# Altium schematic sheet Schematic.SchDoc
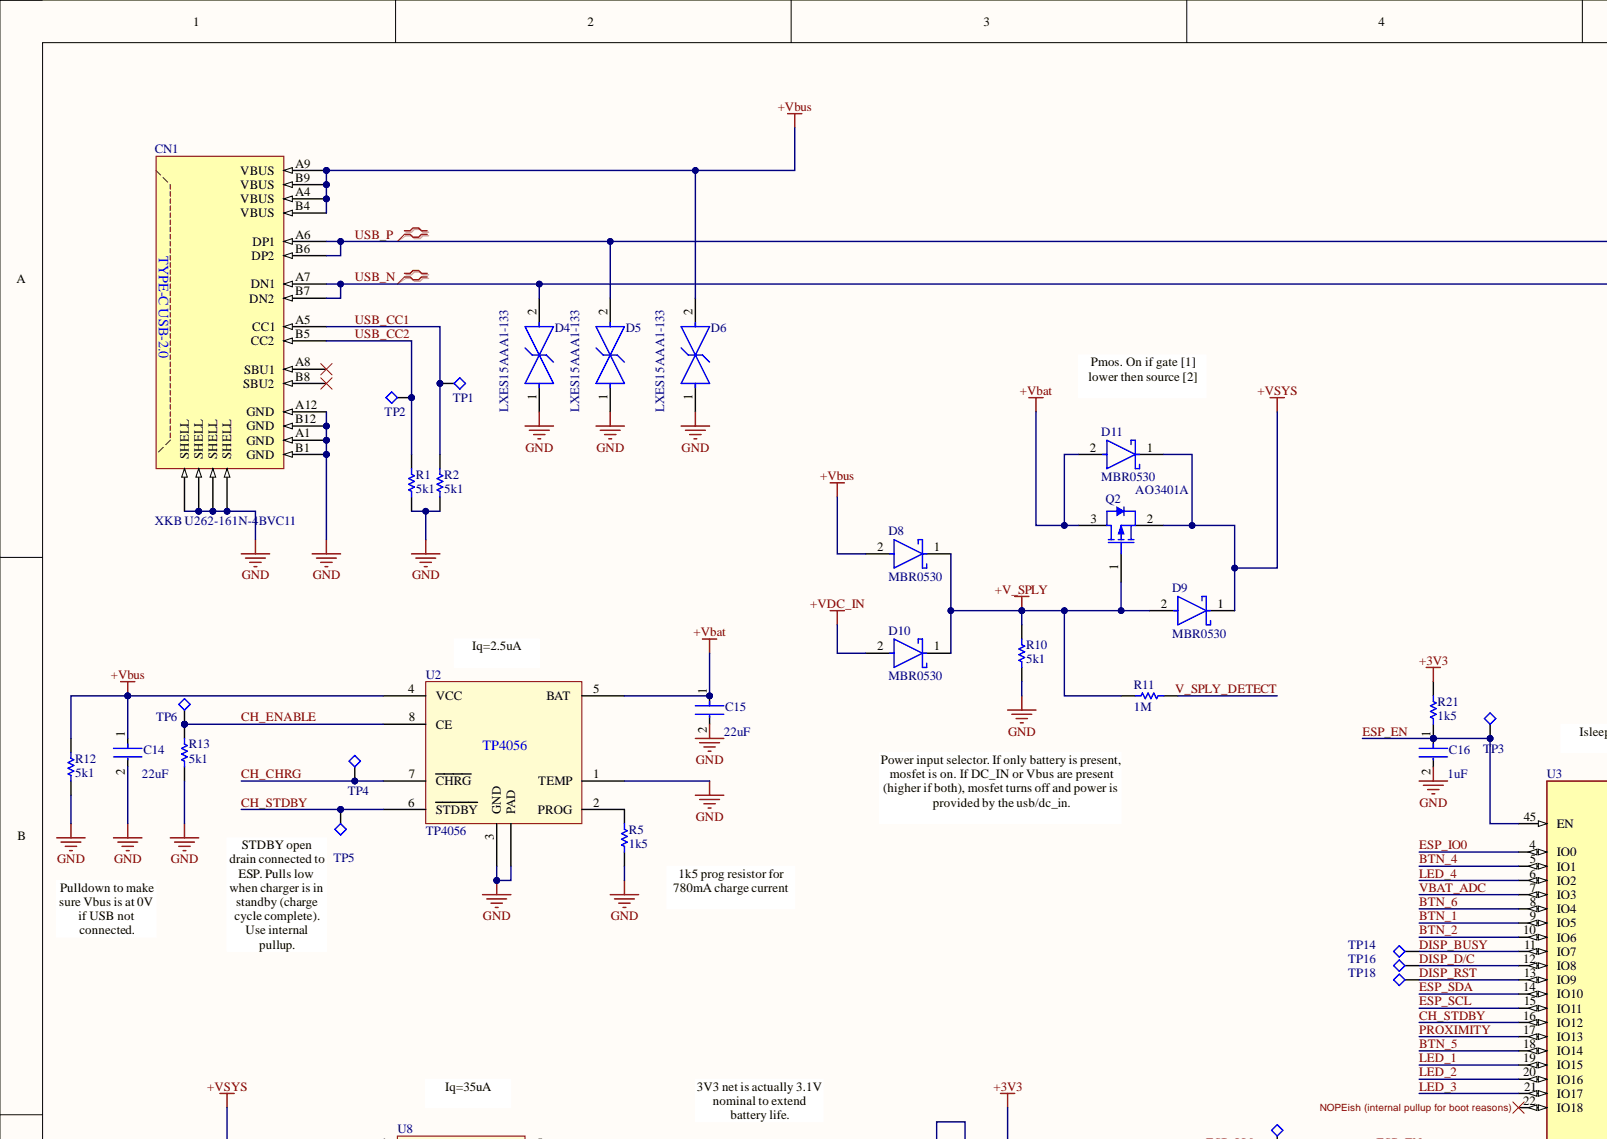
[diagram p0: Schematic.SchDoc, top left]
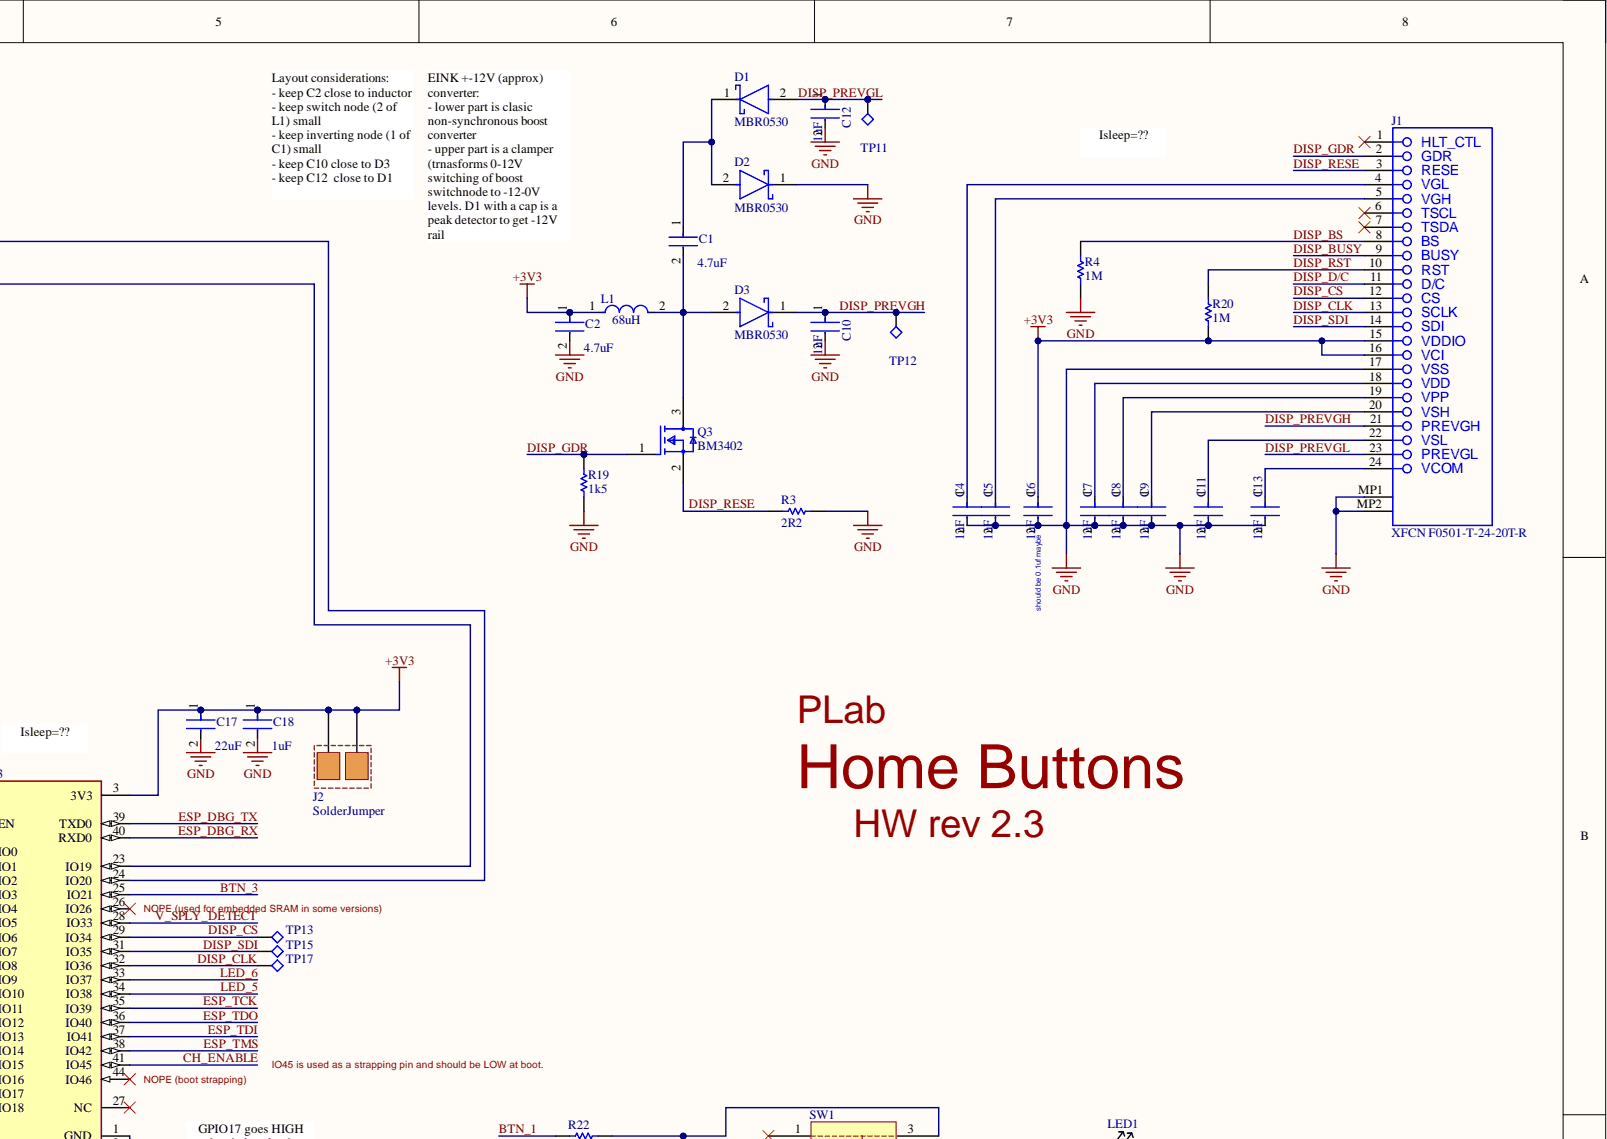
[diagram p1: Schematic.SchDoc, top right]
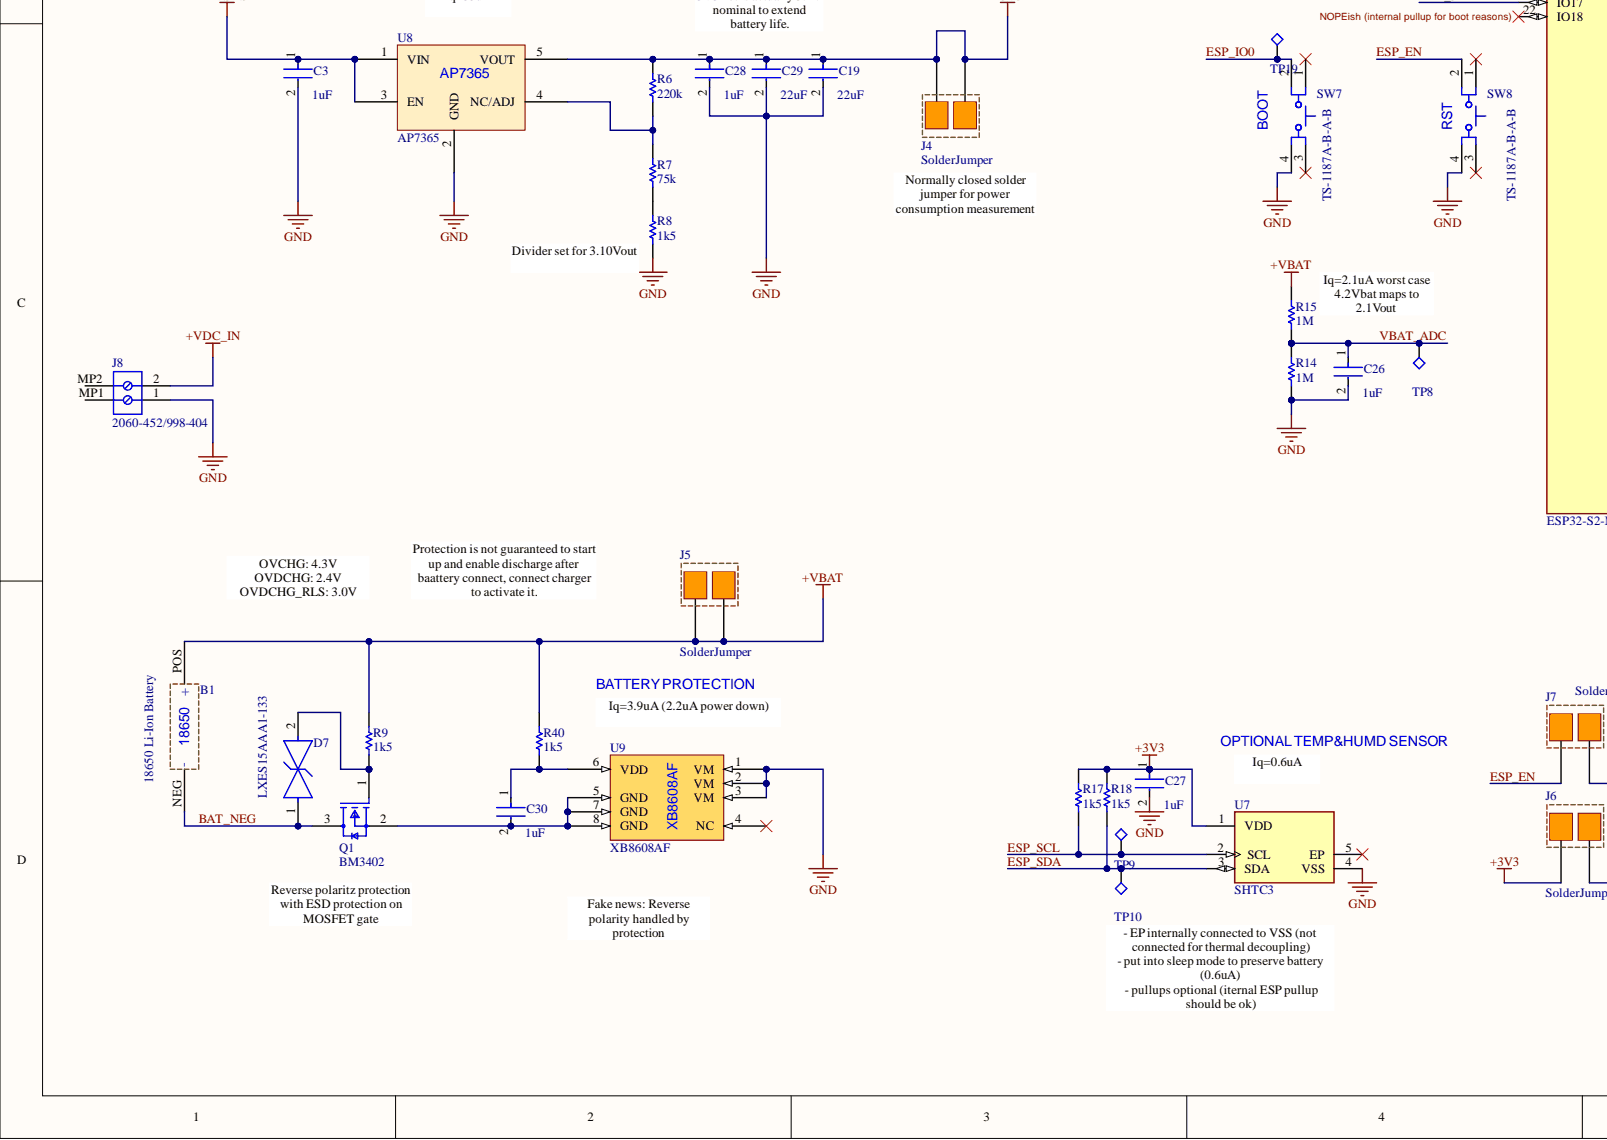
[diagram p2: Schematic.SchDoc, bottom left]
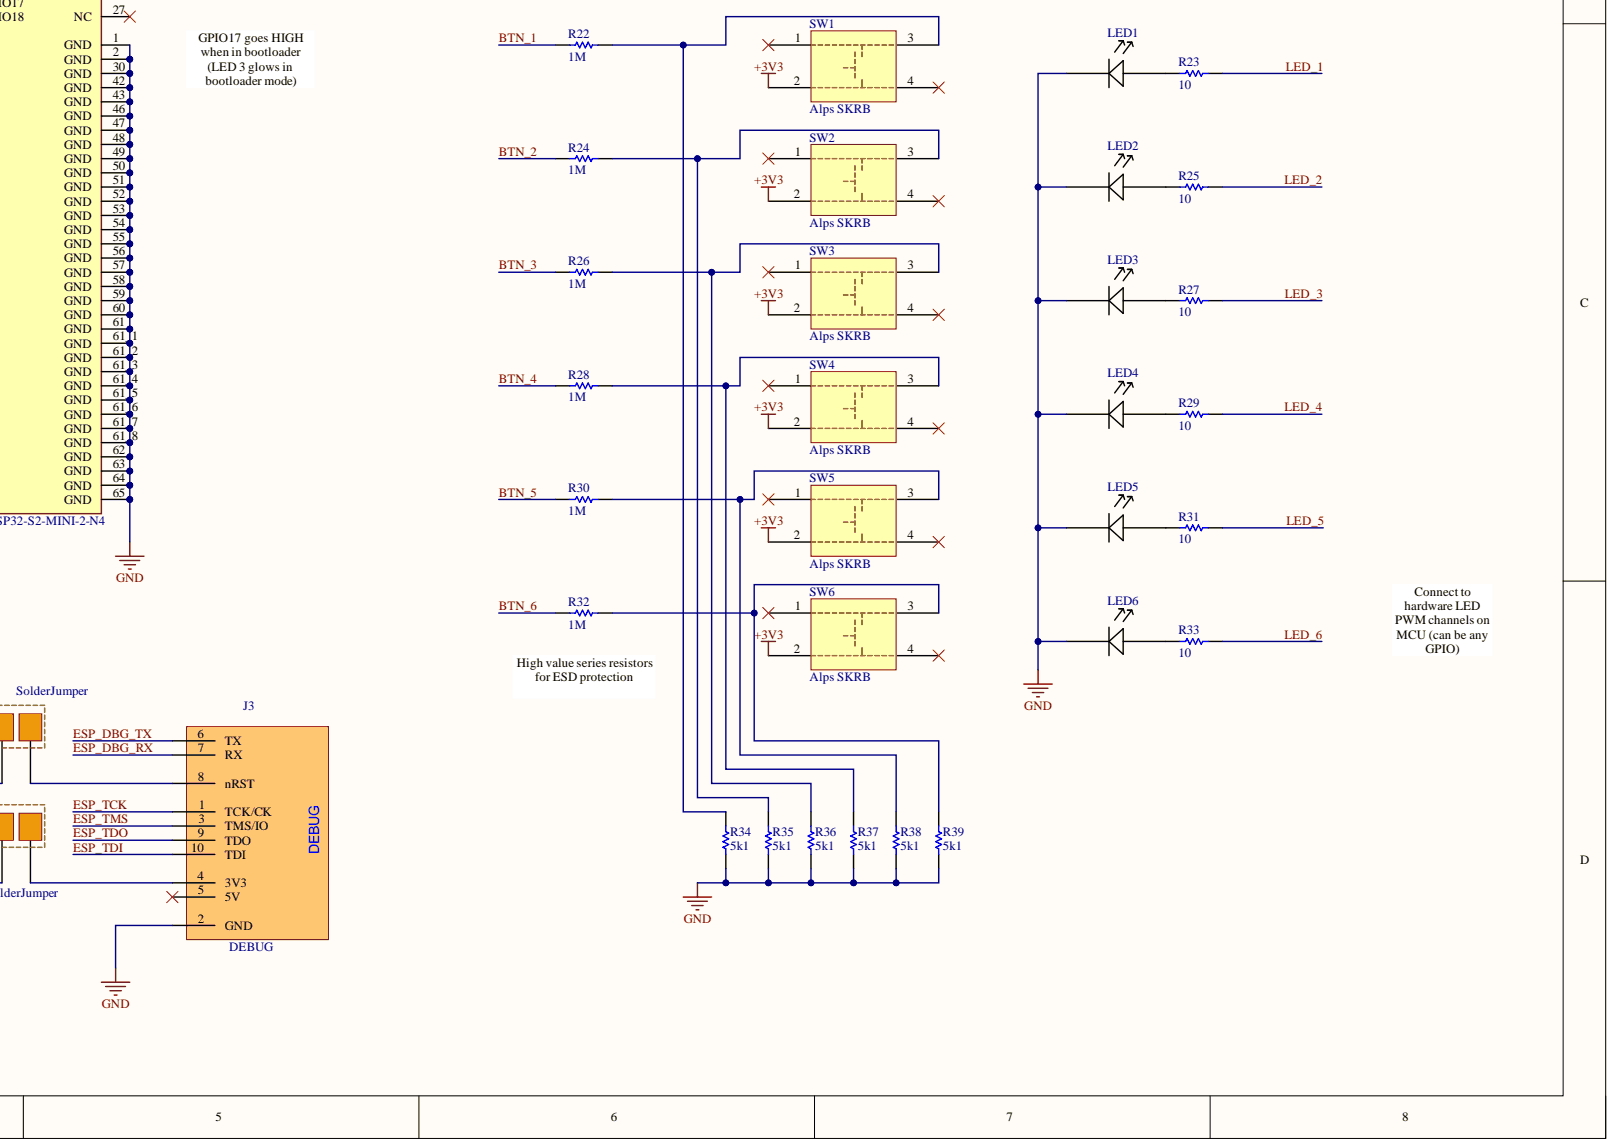
[diagram p3: Schematic.SchDoc, bottom right]

## Parts
B1  18650 Li-Ion Battery  [18650 SMD holder]
C1  Capacitance: 4.7uF  [FP-CL21-IPC_A]  (hidden comment: CL21A475KAQNNNE)
C2  Capacitance: 4.7uF  [FP-CL21-IPC_A]  (hidden comment: CL21A475KAQNNNE)
C3  value 1uF  Capacitance: 1uF  [FP-CL10B105KO8NNNC-IPC_B]  (hidden comment: CL10A105KB8NNNC)
C4  value 1uF  Capacitance: 1uF  [FP-CL10B105KO8NNNC-IPC_B]  (hidden comment: CL10A105KB8NNNC)
C5  value 1uF  Capacitance: 1uF  [FP-CL10B105KO8NNNC-IPC_B]  (hidden comment: CL10A105KB8NNNC)
C6  value 1uF  Capacitance: 1uF  [FP-CL10B105KO8NNNC-IPC_B]  (hidden comment: CL10A105KB8NNNC)
C7  value 1uF  Capacitance: 1uF  [FP-CL10B105KO8NNNC-IPC_B]  (hidden comment: CL10A105KB8NNNC)
C8  value 1uF  Capacitance: 1uF  [FP-CL10B105KO8NNNC-IPC_B]  (hidden comment: CL10A105KB8NNNC)
C9  value 1uF  Capacitance: 1uF  [FP-CL10B105KO8NNNC-IPC_B]  (hidden comment: CL10A105KB8NNNC)
C10  value 1uF  Capacitance: 1uF  [FP-CL10B105KO8NNNC-IPC_B]  (hidden comment: CL10A105KB8NNNC)
C11  value 1uF  Capacitance: 1uF  [FP-CL10B105KO8NNNC-IPC_B]  (hidden comment: CL10A105KB8NNNC)
C12  value 1uF  Capacitance: 1uF  [FP-CL10B105KO8NNNC-IPC_B]  (hidden comment: CL10A105KB8NNNC)
C13  value 1uF  Capacitance: 1uF  [FP-CL10B105KO8NNNC-IPC_B]  (hidden comment: CL10A105KB8NNNC)
C14  Capacitance: 22uF  [FP-CL31-IPC_B]  (hidden comment: CL31A226KPHNNNE)
C15  Capacitance: 22uF  [FP-CL31-IPC_B]  (hidden comment: CL31A226KPHNNNE)
C16  value 1uF  Capacitance: 1uF  [FP-CL10B105KO8NNNC-IPC_B]  (hidden comment: CL10A105KB8NNNC)
C17  Capacitance: 22uF  [FP-CL31-IPC_B]  (hidden comment: CL31A226KPHNNNE)
C18  value 1uF  Capacitance: 1uF  [FP-CL10B105KO8NNNC-IPC_B]  (hidden comment: CL10A105KB8NNNC)
C19  Capacitance: 22uF  [FP-CL31-IPC_B]  (hidden comment: CL31A226KPHNNNE)
C26  value 1uF  Capacitance: 1uF  [FP-CL10B105KO8NNNC-IPC_B]  (hidden comment: CL10A105KB8NNNC)
C27  value 1uF  Capacitance: 1uF  [FP-CL10B105KO8NNNC-IPC_B]  (hidden comment: CL10A105KB8NNNC)
C28  value 1uF  Capacitance: 1uF  [FP-CL10B105KO8NNNC-IPC_B]  (hidden comment: CL10A105KB8NNNC)
C29  Capacitance: 22uF  [FP-CL31-IPC_B]  (hidden comment: CL31A226KPHNNNE)
C30  value 1uF  Capacitance: 1uF  [FP-CL10B105KO8NNNC-IPC_B]  (hidden comment: CL10A105KB8NNNC)
CN1  XKB U262-161N-4BVC11  [XKB U262-161N-4BVC11]
D1  MBR0530  [FP-425-04-IPC_B]
D2  MBR0530  [FP-425-04-IPC_B]
D3  MBR0530  [FP-425-04-IPC_B]
D4  LXES15AAA1-133  [FP-LXES15-IPC_A]
D5  LXES15AAA1-133  [FP-LXES15-IPC_A]
D6  LXES15AAA1-133  [FP-LXES15-IPC_A]
D7  LXES15AAA1-133  [FP-LXES15-IPC_A]
D8  MBR0530  [FP-425-04-IPC_B]
D9  MBR0530  [FP-425-04-IPC_B]
D10  MBR0530  [FP-425-04-IPC_B]
D11  MBR0530  [FP-425-04-IPC_B]
J1  XFCN F0501-T-24-20T-R  [XFCN F0501-T-24-20T-R]
J2  SolderJumper  [SolderJumper_1mm]  (jumper)
J3  DEBUG  [muselab cmsis-dap frictionfit]
J4  SolderJumper  [SolderJumper_1mm]  (jumper)
J5  SolderJumper  [SolderJumper_1mm]  (jumper)
J6  SolderJumper  [SolderJumper_1mm]  (jumper)
J7  SolderJumper  [SolderJumper_1mm]  (jumper)
J8  2060-452/998-404  [WAGO-2060-452_V]
L1  value 68uH  [FP-DFE322512C-IPC_B]  (hidden comment: CMI322513J680MT)
LED1  Everlight Elec 23-21B/T1D-CP2Q2TY/2A  [Everlight Elec 23-21B/T1D-CP2Q2TY/2A]  (value not drawn)
LED2  Everlight Elec 23-21B/T1D-CP2Q2TY/2A  [Everlight Elec 23-21B/T1D-CP2Q2TY/2A]  (value not drawn)
LED3  Everlight Elec 23-21B/T1D-CP2Q2TY/2A  [Everlight Elec 23-21B/T1D-CP2Q2TY/2A]  (value not drawn)
LED4  Everlight Elec 23-21B/T1D-CP2Q2TY/2A  [Everlight Elec 23-21B/T1D-CP2Q2TY/2A]  (value not drawn)
LED5  Everlight Elec 23-21B/T1D-CP2Q2TY/2A  [Everlight Elec 23-21B/T1D-CP2Q2TY/2A]  (value not drawn)
LED6  Everlight Elec 23-21B/T1D-CP2Q2TY/2A  [Everlight Elec 23-21B/T1D-CP2Q2TY/2A]  (value not drawn)
Q1  BM3402  [FP-SOT23-IPC_B]
Q2  AO3401A  [AO-SOT-23-3L_V]
Q3  BM3402  [FP-SOT23-IPC_B]
R1  value 5k1  [RESC1608X55X30NL15T15]  (hidden comment: 0603WAF5101T5E)
R2  value 5k1  [RESC1608X55X30NL15T15]  (hidden comment: 0603WAF5101T5E)
R3  value 2R2  [RESC1608X55X30ML15T15]  (hidden comment: 0603WAF220KT5E)
R4  value 1M  [RESC1608X55X30NL15T15]  (hidden comment: 0603WAF1004T5E)
R5  value 1k5  [RESC1608X55X30NL15T15]  (hidden comment: 0603WAF1501T5E)
R6  value 220k  [RESC1608X55X30NL15T15]  (hidden comment: 0603WAF2203T5E)
R7  value 75k  [RESC1608X55X30NL15T15]  (hidden comment: 0603WAF7502T5E)
R8  value 1k5  [RESC1608X55X30NL15T15]  (hidden comment: 0603WAF1501T5E)
R9  value 1k5  [RESC1608X55X30NL15T15]  (hidden comment: 0603WAF1501T5E)
R10  value 5k1  [RESC1608X55X30NL15T15]  (hidden comment: 0603WAF5101T5E)
R11  value 1M  [RESC1608X55X30NL15T15]  (hidden comment: 0603WAF1004T5E)
R12  value 5k1  [RESC1608X55X30NL15T15]  (hidden comment: 0603WAF5101T5E)
R13  value 5k1  [RESC1608X55X30NL15T15]  (hidden comment: 0603WAF5101T5E)
R14  value 1M  [RESC1608X55X30NL15T15]  (hidden comment: 0603WAF1004T5E)
R15  value 1M  [RESC1608X55X30NL15T15]  (hidden comment: 0603WAF1004T5E)
R17  value 1k5  [RESC1608X55X30NL15T15]  (hidden comment: 0603WAF1501T5E)
R18  value 1k5  [RESC1608X55X30NL15T15]  (hidden comment: 0603WAF1501T5E)
R19  value 1k5  [RESC1608X55X30NL15T15]  (hidden comment: 0603WAF1501T5E)
R20  value 1M  [RESC1608X55X30NL15T15]  (hidden comment: 0603WAF1004T5E)
R21  value 1k5  [RESC1608X55X30NL15T15]  (hidden comment: 0603WAF1501T5E)
R22  value 1M  [RESC1608X55X30NL15T15]  (hidden comment: 0603WAF1004T5E)
R23  value 10  [RESC1608X55X30NL15T15]  (hidden comment: 0603WAF100JT5E)
R24  value 1M  [RESC1608X55X30NL15T15]  (hidden comment: 0603WAF1004T5E)
R25  value 10  [RESC1608X55X30NL15T15]  (hidden comment: 0603WAF100JT5E)
R26  value 1M  [RESC1608X55X30NL15T15]  (hidden comment: 0603WAF1004T5E)
R27  value 10  [RESC1608X55X30NL15T15]  (hidden comment: 0603WAF100JT5E)
R28  value 1M  [RESC1608X55X30NL15T15]  (hidden comment: 0603WAF1004T5E)
R29  value 10  [RESC1608X55X30NL15T15]  (hidden comment: 0603WAF100JT5E)
R30  value 1M  [RESC1608X55X30NL15T15]  (hidden comment: 0603WAF1004T5E)
R31  value 10  [RESC1608X55X30NL15T15]  (hidden comment: 0603WAF100JT5E)
R32  value 1M  [RESC1608X55X30NL15T15]  (hidden comment: 0603WAF1004T5E)
R33  value 10  [RESC1608X55X30NL15T15]  (hidden comment: 0603WAF100JT5E)
R34  value 5k1  [RESC1608X55X30NL15T15]  (hidden comment: 0603WAF5101T5E)
R35  value 5k1  [RESC1608X55X30NL15T15]  (hidden comment: 0603WAF5101T5E)
R36  value 5k1  [RESC1608X55X30NL15T15]  (hidden comment: 0603WAF5101T5E)
R37  value 5k1  [RESC1608X55X30NL15T15]  (hidden comment: 0603WAF5101T5E)
R38  value 5k1  [RESC1608X55X30NL15T15]  (hidden comment: 0603WAF5101T5E)
R39  value 5k1  [RESC1608X55X30NL15T15]  (hidden comment: 0603WAF5101T5E)
R40  value 1k5  [RESC1608X55X30NL15T15]  (hidden comment: 0603WAF1501T5E)
SW1  Alps SKRB  [Alps SKRB]
SW2  Alps SKRB  [Alps SKRB]
SW3  Alps SKRB  [Alps SKRB]
SW4  Alps SKRB  [Alps SKRB]
SW5  Alps SKRB  [Alps SKRB]
SW6  Alps SKRB  [Alps SKRB]
SW7  TS-1187A-B-A-B  [ESWI-TL3342F160QG_V]
SW8  TS-1187A-B-A-B  [ESWI-TL3342F160QG_V]
TP1  test point 1.5mm  [TP1_FULL]  (value not drawn)
TP2  test point 1.5mm  [TP1_FULL]  (value not drawn)
TP3  test point 1.5mm  [TP1_FULL]  (value not drawn)
TP4  test point 1.5mm  [TP1_FULL]  (value not drawn)
TP5  test point 1.5mm  [TP1_FULL]  (value not drawn)
TP6  test point 1.5mm  [TP1_FULL]  (value not drawn)
TP8  test point 1.5mm  [TP1_FULL]  (value not drawn)
TP9  test point 1.5mm  [TP1_FULL]  (value not drawn)
TP10  test point 1.5mm  [TP1_FULL]  (value not drawn)
TP11  test point 1.5mm  [TP1_FULL]  (value not drawn)
TP12  test point 1.5mm  [TP1_FULL]  (value not drawn)
TP13  test point 1.5mm  [TP1_FULL]  (value not drawn)
TP14  test point 1.5mm  [TP1_FULL]  (value not drawn)
TP15  test point 1.5mm  [TP1_FULL]  (value not drawn)
TP16  test point 1.5mm  [TP1_FULL]  (value not drawn)
TP17  test point 1.5mm  [TP1_FULL]  (value not drawn)
TP18  test point 1.5mm  [TP1_FULL]  (value not drawn)
TP19  test point 1.5mm  [TP1_FULL]  (value not drawn)
U2  TP4056  [Generic SOP8-PP]
U3  ESP32-S2-MINI-2-N4  [XCVR_ESP32-S2-MINI-1]
U7  SHTC3  [FP-SHTC3-MFG]
U8  AP7365  [Diodes Inc SOT25]
U9  XB8608AF  [generic sop8]

## Nets
+3V3: C2.1 C6.1 C17.1 C18.1 C19.1 C27.1 C28.1 C29.1 J1.15 J1.16 J2.1 J2.2 J4.1 J4.2 J6.1 L1.1 R6.2 R17.2 R18.2 R20.1 R21.2 SW1.2 SW2.2 SW3.2 SW4.2 SW5.2 SW6.2 U3.3(3V3) U7.1(VDD) U8.5(VOUT)
+V_SPLY: D8.1 D9.2 D10.1 Q2.1 R10.2 R11.1
+Vbat: B1.POS C15.1 D11.2 J5.1 J5.2 Q2.3 R9.2 R15.2 R40.2 U2.5(BAT)
+Vbus: C14.1 CN1.A4(VBUS) CN1.A9(VBUS) CN1.B4(VBUS) CN1.B9(VBUS) D6.2 D8.2 R12.2 U2.4(VCC)
+VDC_IN: D10.2 J8.2
+VSYS: C3.1 D9.1 D11.1 Q2.2 U8.1(VIN) U8.3(EN)
GND: C2.2 C3.2 C4.2 C5.2 C6.2 C7.2 C8.2 C9.2 C10.2 C11.2 C12.2 C13.2 C14.2 C15.2 C16.2 C17.2 C18.2 C19.2 C26.2 C27.2 C28.2 C29.2 CN1.A1(GND) CN1.A12(GND) CN1.B1(GND) CN1.B12(GND) CN1.SHELL1(SHELL) CN1.SHELL2(SHELL) CN1.SHELL3(SHELL) CN1.SHELL4(SHELL) D2.1 D4.1 D5.1 D6.1 J1.17 J1.MP1 J1.MP2 J3.2(GND) J8.1 LED1.2 LED2.2 LED3.2 LED4.2 LED5.2 LED6.2 R1.1 R2.1 R3.2 R4.1 R5.1 R8.1 R10.1 R12.1 R13.1 R14.1 R19.1 R34.1 R35.1 R36.1 R37.1 R38.1 R39.1 SW7.4 SW8.4 U2.1(TEMP) U2.3(GND) U2.9(PAD) U3.1(GND) U3.2(GND) U3.30(GND) U3.42(GND) U3.43(GND) U3.46(GND) U3.47(GND) U3.48(GND) U3.49(GND) U3.50(GND) U3.51(GND) U3.52(GND) U3.53(GND) U3.54(GND) U3.55(GND) U3.56(GND) U3.57(GND) U3.58(GND) U3.59(GND) U3.60(GND) U3.61(GND) U3.61_1(GND) U3.61_2(GND) U3.61_3(GND) U3.61_4(GND) U3.61_5(GND) U3.61_6(GND) U3.61_7(GND) U3.61_8(GND) U3.62(GND) U3.63(GND) U3.64(GND) U3.65(GND) U7.4(VSS) U8.2(GND) U9.1(VM) U9.2(VM) U9.3(VM)
BAT_NEG: B1.NEG D7.1 Q1.3
BTN_1: R22.1 U3.9(IO5)
BTN_2: R24.1 U3.10(IO6)
BTN_3: R26.1 U3.25(IO21)
BTN_4: R28.1 U3.5(IO1)
BTN_5: R30.1 U3.18(IO14)
BTN_6: R32.2 U3.8(IO4)
CH_CHRG: TP4.1 U2.7(CHRG)
CH_ENABLE: R13.2 TP6.1 U2.8(CE) U3.41(IO45)
CH_STDBY: TP5.1 U2.6(STDBY) U3.16(IO12)
DISP_BS: J1.8 R4.2
DISP_BUSY: J1.9 TP14.1 U3.11(IO7)
DISP_CLK: J1.13 TP17.1 U3.32(IO36)
DISP_CS: J1.12 TP13.1 U3.29(IO34)
DISP_D/C: J1.11 TP16.1 U3.12(IO8)
DISP_GDR: J1.2 Q3.1 R19.2
DISP_PREVGH: C10.1 D3.1 J1.21 TP12.1
DISP_PREVGL: C12.1 D1.2 J1.23 TP11.1
DISP_RESE: J1.3 Q3.2 R3.1
DISP_RST: J1.10 R20.2 TP18.1 U3.13(IO9)
DISP_SDI: J1.14 TP15.1 U3.31(IO35)
ESP_DBG_RX: J3.7(RX) U3.40(RXD0)
ESP_DBG_TX: J3.6(TX) U3.39(TXD0)
ESP_EN: C16.1 J7.1 R21.1 SW8.2 TP3.1 U3.45(EN)
ESP_IO0: SW7.2 TP19.1 U3.4(IO0)
ESP_SCL: R17.1 TP9.1 U3.15(IO11) U7.2(SCL)
ESP_SDA: R18.1 TP10.1 U3.14(IO10) U7.3(SDA)
ESP_TCK: J3.1(TCK/CK) U3.35(IO39)
ESP_TDI: J3.10(TDI) U3.37(IO41)
ESP_TDO: J3.9(TDO) U3.36(IO40)
ESP_TMS: J3.3(TMS/IO) U3.38(IO42)
LED_1: R23.1 U3.19(IO15)
LED_2: R25.1 U3.20(IO16)
LED_3: R27.1 U3.21(IO17)
LED_4: R29.1 U3.6(IO2)
LED_5: R31.1 U3.34(IO38)
LED_6: R33.1 U3.33(IO37)
PROXIMITY: U3.17(IO13)
USB_CC1: CN1.A5(CC1) R2.2 TP1.1
USB_CC2: CN1.B5(CC2) R1.2 TP2.1
USB_N: CN1.A7(DN1) CN1.B7(DN2) D4.2 U3.23(IO19)
USB_P: CN1.A6(DP1) CN1.B6(DP2) D5.2 U3.24(IO20)
V_SPLY_DETECT: R11.2 U3.28(IO33)
VBAT_ADC: C26.1 R14.2 R15.1 TP8.1 U3.7(IO3)
NetC1_1: C1.1 D1.1 D2.2
NetC1_2: C1.2 D3.2 L1.2 Q3.3
NetC4_1: C4.1 J1.4
NetC5_1: C5.1 J1.5
NetC7_1: C7.1 J1.18
NetC8_1: C8.1 J1.19
NetC9_1: C9.1 J1.20
NetC11_1: C11.1 J1.22
NetC13_1: C13.1 J1.24
NetC30_1: C30.1 R40.1 U9.6(VDD)
NetC30_2: C30.2 Q1.2 U9.5(GND) U9.7(GND) U9.8(GND)
NetD7_2: D7.2 Q1.1 R9.1
NetJ3_4: J3.4(3V3) J6.2
NetJ3_8: J3.8(nRST) J7.2
NetLED1_1: LED1.1 R23.2
NetLED2_1: LED2.1 R25.2
NetLED3_1: LED3.1 R27.2
NetLED4_1: LED4.1 R29.2
NetLED5_1: LED5.1 R31.2
NetLED6_1: LED6.1 R33.2
NetR5_2: R5.2 U2.2(PROG)
NetR6_1: R6.1 R7.2 U8.4(NC/ADJ)
NetR7_1: R7.1 R8.2
NetR22_2: R22.2 R34.2 SW1.3
NetR24_2: R24.2 R35.2 SW2.3
NetR26_2: R26.2 R36.2 SW3.3
NetR28_2: R28.2 R37.2 SW4.3
NetR30_2: R30.2 R38.2 SW5.3
NetR32_1: R32.1 R39.2 SW6.3

Not connected: CN1 A8(SBU1) B8(SBU2); J1 1 6 7; J3 5(5V); J8 MP1 MP2; SW1 1 4; SW2 1 4; SW3 1 4; SW4 1 4; SW5 1 4; SW6 1 4; SW7 1 3; SW8 1 3; U3 22(IO18) 26(IO26) 27(NC) 44(IO46); U7 5(EP); U9 4(NC)

## Library data of the parts
B1 = 18650 Li-Ion Battery: Lithium 18650 battery cell; Component Kind: Standard; Library Name: planinsekLib_sch.SchLib
C1 C2 = CMP-13271-002624-1: CL21 Series 0805 4.7uF 25V ±10% Tolerance X5R Multilayer Ceramic Chip Capacitor; Case Code (Imperial): 0805; Case Code (Metric): 2012; Case/Package: 0805; Component Kind: Standard; Depth: 1.25mm; Dielectric: X5R; Height: 1.25mm; LCSC: C1779; Lead Free: Lead Free; Length: 2mm; Library Name: Altium Content Vault; Max Operating Temperature: 85°C; Min Operating Temperature: -55°C; Mount: Surface Mount; Package Quantity: 1; Packaging: Tape and Reel; Radiation Hardening: No; Thickness: 0.055inch; Tolerance: 10%; Voltage Rating: 25V; Voltage Rating (DC): 25V; Width: 0.049inch
C3-C13 C16 C18 C26-C28 C30 = CMP-2000-06093-2: CAP CER 1UF 50V X5R 0603; Manufacturer: Samsung Electro-Mechanics America, Inc; Manufacturer Part Number: CL10B105KO8NNNC; Material: Ceramic; Temperature Coefficient: 15%; Tolerance: 10%; Automotive: No; Category: Cap; Component Kind: Standard; ComponentLink2Description: Datasheet; ComponentLink2URL: http://www.samsungsem.com/global/front/downloadcms.do?path=%2F%2Fglobal%2F%2Fsupport%2F%2Fproduct-search%2F%2Fmlcc%2F%2F__icsFiles%2F%2Fafieldfile%2F%2F2019%2F%2F06%2F%2F18&fileName=CL10B105KO8NNNC-19.pdf; Device Class L1: Passive Components; Device Class L2: Capacitors; Device Class L3: Ceramic Capacitors; Digikey Description: CAP CER 1UF 16V X7R 0603; Height: 0.9mm; Ipc Land Pattern Name: CAPC16080X80; LCSC: C15849; Lead Free: Yes; Library Name: Altium Content Vault; Octopart: https://octopart.com/cl10b105ko8nnnc-samsung-19018973; Package: CL10B105KO8NNNC; RoHS: TRUE; Temperature: -55°C to +125°C; Temperature Characteristic: X5R; Temperature Range High: +125°C; Temperature Range Low: -55°C; Voltage Rating: 50V
C14 C15 C17 C19 C29 = CMP-13271-002604-1: Cap Ceramic 22uF 10V X5R ±10% SMD 1206; Case Code (Imperial): 1206; Case Code (Metric): 3216; Case/Package: 1206; Component Kind: Standard; Depth: 1.6mm; Dielectric: X5R; Height: 1.6mm; LCSC: C5672; Lead Free: Lead Free; Length: 3.2mm; Library Name: Altium Content Vault; Max Operating Temperature: 85°C; Min Operating Temperature: -55°C; Mount: Surface Mount; Package Quantity: 1; Packaging: Tape and Reel; Radiation Hardening: No; Thickness: 0.071inch; Tolerance: 10%; Voltage Rating: 10V; Voltage Rating (DC): 10V; Width: 0.063inch
CN1 = XKB U262-161N-4BVC11: USB Type-C connector, USB-2.0, 16 pins; Component Kind: Standard; LCSC: C319148; Library Name: planinsekLib_sch.SchLib
D1-D3 D8-D11 = CMP-2000-05113-2: DIODE SCHOTTKY 30V 500MA SOD123; Element Configuration: Single; Forward Voltage: 430mV; Max Forward Surge Current (Ifsm): 5.5A; Max Reverse Leakage Current: 130uA; Polarity: Standard; Average Rectified Current: 500mA; Component Kind: Standard; Contact Plating: Tin; Current Rating: 500mA; Forward Current: 500mA; Height: 1.03mm; LCSC: C77336; Lead Free: Lead Free; Length: 2.7mm; Library Name: Altium Content Vault; Max Operating Temperature: 150°C; Max Repetitive Reverse Voltage (Vrrm): 30V; Max Reverse Voltage (DC): 30V; Max Surge Current: 5.5A; Min Operating Temperature: -65°C; Mount: Surface Mount; Number of Pins: 2; Output Current: 500mA; Package Quantity: 1; Packaging: Tape and Reel; Peak Non-Repetitive Surge Current: 5.5A; Peak Reverse Current: 130uA; Radiation Hardening: No; REACH SVHC: No SVHC; Voltage Rating (DC): 30V; Weight: 0.03g; Width: 1.6mm
D4-D7 = CMP-06195-000011-1: TVS DIODE 15V 1005; Number of Bidirectional Channels: 1; Power Line Protection: No; Case Code (Imperial): 0402; Case Code (Metric): 1005; Case/Package: 0402; Component Kind: Standard; LCSC: C191267; Library Name: Altium Content Vault; Max Operating Temperature: 85°C; Min Operating Temperature: -40°C; Mount: Surface Mount; Packaging: Tape and Reel; Resistor Type: Zener; Reverse Standoff Voltage: 15V
J1 = XFCN F0501-T-24-20T-R: XFCN F0501-T-24-20T-R 0.5mm fpc top contacts, slide retentioner; Component Kind: Standard; LCSC: C840496; Library Name: planinsekLib_sch.SchLib
J3 = MuseLab CMSIS-DAP probe receiving connector: Component Kind: Standard; Library Name: planinsekLib_sch.SchLib
J8 = CMP-2000-05527-1: Terminal Block, Pitch 4 mm, 1 x 2 Position, Height 4.5 mm, -60 to 105 degC, RoHS, Tape and Reel; Manufacturer: WAGO; AWG: 24-18; Connection: Pullspring; Content: 1pc(s); Max. cross section (flexible): 0.75mm²; Min. cross section (flexible): 0.25mm²; Min. cross section (unflexible): 0.2mm²; Nominal current: 9A; Nominal voltage: 160V; Number of contacts: 2; Pitch: 4mm; Stripping length: 6-7mm; Colour: White; Component Kind: Standard; Contact material: Tinplated; Datasheet URL: http://www.wago.com/infomaterial/pdf/60255126.pdf; Datasheet Version: 03/2015; Height: 4.5mm; Length: 7.9mm; Library Name: wago_connectors.SchLib; Manufacturer part No.: 2060-452/998-404; Manufacturer URL: http://global.wago.com/en/index-en.jsp; Material: PPA-GFenclosure·Tin-platedcoppercontacts; Max. cross section (inflexible): 0.75mm²; Mounting Technology: SurfaceMount; Package Description: Terminal Block, Pitch 4 mm, 1 x 2 Position, Height 4.5 mm; Package Reference: 2060-452; Type: 2060-452/998-404; Width: 10.1mm
L1 = CMP-06042-000782-1: CMI322513J680MT 1210 chip SMD inductor 68uH; Component Kind: Standard; LCSC: C401470; Library Name: Altium Content Vault
LED1-LED6 = Everlight Elec 23-21B/T1D-CP2Q2TY/2A: reverse mount SMD led, cool white; Component Kind: Standard; LCSC: C414638; Library Name: planinsekLib_sch.SchLib
Q1 Q3 = CMP-83955-000006-1: BORN BM3402 MOSFET N-CH 30V 5.1A SOT23; Component Kind: Standard; LCSC: C431498; Library Name: Altium Content Vault
Q2 = CMP-2000-05778-1: P-Channel MOSFET, 30 V, 4 A, -55 to 150 degC, 3-Pin SOT-23-3L, RoHS, Tape and Reel; Manufacturer: Alpha and Omega; Channel Mode: Enhancement; Continuous Drain Current: 4A; Drain Efficiency: NotRequired%; Drain-Source On-Res: 0.044ohm; Drain-Source On-Volt: 30V; Frequency (Max): NotRequiredMHz; Gate-Source Voltage (Max): ?12V; Noise Figure: NotRequireddB; Number of  Elements: 1; Output Power (Max): NotRequiredW; Polarity: P; Power Dissipation: 1.4W; Rad Hardened: No; Type: PowerMOSFET; Component Kind: Standard; Datasheet URL: http://www.aosmd.com/res/data_sheets/AO3401A.pdf; Datasheet Version: Rev. 3, 03/2011; Drain Current (Max): 4A; LCSC: C15127; Library Name: Altium Content Vault; Manufacturer URL: http://www.aosmd.com/; Mounting: SurfaceMount; Mounting Technology: SurfaceMount; Operating Temp Range: -55Cto150C; Operating Temperature Classification: Military; Package Description: 3-Pin Small Outline Transistor, Body 2.95 x 1.6 mm, Pitch 0.95 mm; Package Reference: SOT-23-3L; Package Type: SOT-23; Package URL: http://www.aosmd.com/res/packaging_information/SOT-23-3L.pdf; Pin Count: 3; Power Gain: NotRequireddB
R1 R2 R6 R7 R10 R12 R13 R23 R25 R27 R29 R31 R33-R39 = CMP-2000-00540-1: Manufacturer: UNI_ROYAL; Component Kind: Standard; Library Name: Altium Content Vault
  R1 R2 R10 R12 R13 R34-R39: LCSC: C23186; Part Number: 0603WAF5101T5E
  R6: LCSC: C22961; Part Number: 0603WAF2203T5E
  R7: LCSC: C23242; Part Number: 0603WAF7502T5E
  R23 R25 R27 R29 R31 R33: LCSC: C22859; Part Number: 0603WAF100JT5E
R3 = CMP-2000-00787-1: Manufacturer: UNI-ROYAL; Component Kind: Standard; LCSC: C22939; Library Name: Altium Content Vault; Part Number: 0603WAF220KT5E
R4 R11 R14 R15 R20 R22 R24 R26 R28 R30 R32 = CMP-1012-00849-2: Manufacturer: Panasonic; Component Kind: Standard; LCSC: C22935; Library Name: Altium Content Vault; Part Number: 0603WAF1004T5E
R5 R8 R9 R17-R19 R21 R40 = CMP-2000-00540-1: Manufacturer: UNI_ROYAL; Part Number: 0603WAF5101T5E; Component Kind: Standard; LCSC: C22843; Library Name: Altium Content Vault
SW1-SW6 = Alps SKRB: SKRB series SMD tactile button Alps Alpine; Variant: SKRBAKE010; Component Kind: Standard; ComponentLink1Description: Datasheet; ComponentLink1URL: https://tech.alpsalpine.com/prod/e/html/tact/surfacemount/skrb/skrbake010.html; LCSC: C139790; Library Name: Alps.SchLib
SW7 SW8 = CMP-1696-00003-1: XKB TS-1187A-B-A-B C318884; Component Kind: Standard; LCSC: C318884; Library Name: Altium Content Vault
TP1-TP6 TP8-TP19 = Test Point: Test point ,1.5mm diameter, no hole; Category: testpoints; Component Kind: Standard; Library Name: DAQ.SchLib
U2 = TP4056: 1s Lithium linear battery charger, 1A output; Component Kind: Standard; ComponentLink1Description: Datasheet; ComponentLink1URL: https://static.chipdip.ru/lib/977/DOC002977110.pdf; LCSC: C16581; Library Name: planinsekLib_sch.SchLib
U3 = ESP32-S2-MINI-1: WiFi 802.11b/g/n Transceiver Module 2.412GHz ~ 2.484GHz Antenna Not Included Surface Mount; MF: Espressif Systems; Availability: In Stock; Check_prices: https://www.snapeda.com/parts/ESP32-S2-MINI-1/Espressif+Systems/view-part/?ref=eda; Component Kind: Standard; LCSC: C3013906; Library Name: ESP32-S2-MINI-1.SchLib; MP: ESP32-S2-MINI-1; Package: NON STANDARD-65 Espressif Systems; Price: None; Purchase-URL: https://pricing.snapeda.com/search/part/ESP32-S2-MINI-1/?ref=eda; SnapEDA_Link: https://www.snapeda.com/parts/ESP32-S2-MINI-1/Espressif+Systems/view-part/?ref=snap
U7 = CMP-144040-000003-1: SENSOR HUMI/TEMP I2C 2% SMD; Manufacturer: Sensirion; Max Supply Voltage: 3.6V; Min Supply Voltage: 1.62V; Operating Supply Current: 0.3-900uA; Resolution: 16bits; Accuracy: 2%; Automotive: No; Category: IC; Component Kind: Standard; ComponentLink2Description: Datasheet; ComponentLink2URL: https://www.sensirion.com/fileadmin/user_upload/customers/sensirion/Dokumente/0_Datasheets/Humidity/Sensirion_Humidity_Sensors_SHTC3_Datasheet.pdf; Device Class L1: Sensors; Device Class L2: Temperature and Humidity Sensors; Device Class L3: unset; Digikey Description: SENSOR HUMI/TEMP I2C 2% SMD; Height: 0.75mm; Interface: I2C; Ipc Land Pattern Name: DFN200X200X75-4; LCSC: C2880044; Lead Free: Yes; Library Name: Altium Content Vault; Manufacturer Part Number: SHTC3; Mouser Description: SHTC3 Series 0 to 100% RH 3.6 V Humidity and Temperature Sensor IC - DFN-4; Octopart: https://octopart.com/shtc3-sensirion-91912225; Package: 0; RoHS: TRUE; Sensor Output: I2C; Temperature: -40°C to +125°C; Temperature Range High: +125°C; Temperature Range Low: -40°C
U8 = AP7365: Diodes Inc AP7365 LDO, fast transient, 600mA; Component Kind: Standard; Library Name: planinsekLib_sch.SchLib
U9 = XB8608AF: XYSEMI 1s Li-Ion integrated protection IC; Component Kind: Standard; LCSC: C2681922; Library Name: planinsekLib_sch.SchLib
J2 J4-J7 = SolderJumper: Library Name: planinsekLib_sch.SchLib
